# Altium schematic sheet 06_USB_To_UART.SchDoc
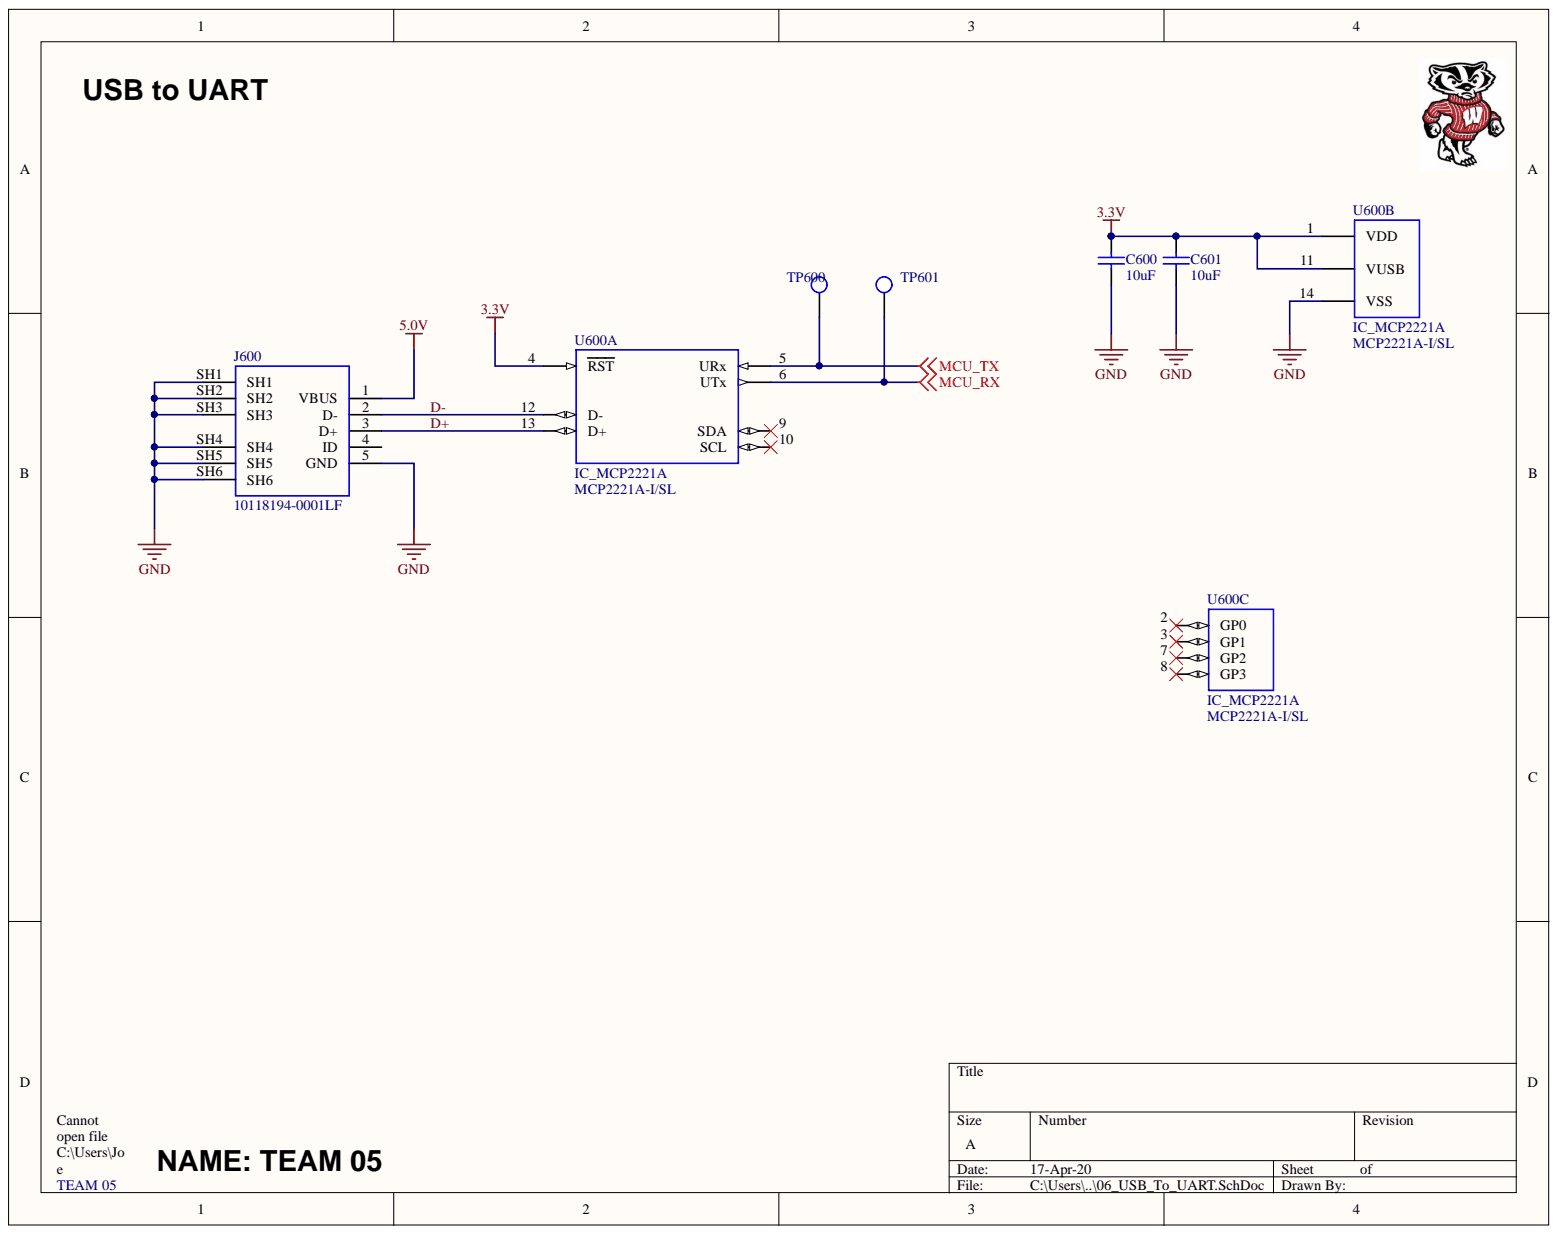
[diagram p0: 06_USB_To_UART.SchDoc]

## Parts
C600  10uF  [CAP_0805]
C601  10uF  [CAP_0805]
J600  Manufacturer Part Number: 10118194-0001LF  [USB_MICRO_B]  (hidden comment: USB_MICRO_B_10118194-0001LF)
TP600  TP_1206  [TP-SMC-1206]  (value not drawn)
TP601  TP_1206  [TP-SMC-1206]  (value not drawn)
U600  IC_MCP2221A  Manufacturer Part Number: MCP2221A-I/SL  [SOIC_MCP2221A]  (parts A,B,C)

## Nets
3.3V: C600.1 C601.1 U600.1(VDD) U600.4(RST) U600.11(VUSB)
5.0V: J600.1(VBUS)
GND: C600.2 C601.2 J600.5(GND) J600.SH1 J600.SH2 J600.SH3 J600.SH4 J600.SH5 J600.SH6 U600.14(VSS)
MCU_RX: TP601.1 U600.6(UTx)
MCU_TX: TP600.1 U600.5(URx)
D+: J600.3(D+) U600.13(D+)
D-: J600.2(D-) U600.12(D-)

Not connected: J600 4(ID); U600 2(GP0) 3(GP1) 7(GP2) 8(GP3) 9(SDA) 10(SCL)

## Library data of the parts
C600 C601 = CAP_0805_U_010.00: CAP CER 10UF 16V X7R 0805; Manufacturer: Samsung; Manufacturer Part Number: CL21A106KPCLQNC; Dielectric: X5R; Tolerance: 10%; Voltage Rating: 10V; Capacitance: 10uF; Case/Package: 0805; Case Code (Imperial): 0805; Case Code (Metric): 2012; Component Kind: Standard; ComponentLink1Description: https://datasheet.ciiva.com/25730/cl21a106kpclqnc-25730594.pdf; ComponentLink1URL: https://datasheet.ciiva.com/25730/cl21a106kpclqnc-25730594.pdf; ComponentLink3Description: https://datasheet.ciiva.com/26920/getdatasheetpartid-355170-26920201.pdf; ComponentLink3URL: https://datasheet.ciiva.com/26920/getdatasheetpartid-355170-26920201.pdf; Contact Plating: Tin; Depth: 1.25mm; Height: 0.85mm; Length: 2mm; Library Name: ece315-S20.SCHLIB; Max Operating Temperature: 85°C; Min Operating Temperature: -55°C; Mount: Surface Mount; Package Quantity: 1; Packaging: Tape and Reel; Pricing 1: 1=0.22, 10=0.148, 100=0.0749, 500=0.05122, 1000=0.04531 (USD); Radiation Hardening: No; Stock 1: 40000; Supplier 1: Digi-Key; Supplier Part Number 1: 1276-2402-1-ND; Thickness: 0.037inch; Width: 0.049inch
J600 = USB_MICRO_B_10118194-0001LF: CONN USB MICRO B RECPT SMT R/A; Manufacturer: Amphenol FCI; Connector Type: USB,Receptacle; Current Rating: 1.8A; Gender: Receptacle; Insulation Resistance: 1GR; Max Voltage Rating (AC): 100V; Number of Contacts: 5; Number of Ports: 1; Pitch: 0.65mm; Color: Black; Component Kind: Standard; Contact Material: Copper Alloy; Contact Plating: Gold; Depth: 5mm; Features: Shielded; Flammability Rating: UL94 V-0; Housing Material: Polymer; Lead Free: Lead Free; Length: 8.05mm; Library Name: ece315-S20.SCHLIB; Max Operating Temperature: 85°C; Min Operating Temperature: -55°C; Mount: Surface Mount,Horizontal; Number of Terminals: 5; Orientation: Right Angle; Package Quantity: 9600; Packaging: Tape and Reel; Radiation Hardening: No; Supplier: Digi-Key; Supplier Part Number: 609-4618-1-ND; Termination: Solder
TP600 TP601 = TP_1206: Test Point SMD 1206; Manufacturer: TE Connectivity (Tyco Electronics); Manufacturer Part Number: 1625854-1; Power Rating: N/R; Resistance Value: 0 Ohm; Case Size: 1206; Component Kind: Standard; ComponentLink1Description: https://datasheet.ciiva.com/6439/eng-ds-1773266-a-6439169.pdf; ComponentLink1URL: https://datasheet.ciiva.com/6439/eng-ds-1773266-a-6439169.pdf; ComponentLink2Description: https://datasheet.ciiva.com/30136/1773266-a-30136341.pdf; ComponentLink2URL: https://datasheet.ciiva.com/30136/1773266-a-30136341.pdf; ComponentLink3Description: https://datasheet.ciiva.com/6439/eng-ds-1773266-a-6439080.pdf; ComponentLink3URL: https://datasheet.ciiva.com/6439/eng-ds-1773266-a-6439080.pdf; ComponentLink4Description: https://datasheet.ciiva.com/6439/eng-ds-1773266-a-6439081.pdf; ComponentLink4URL: https://datasheet.ciiva.com/6439/eng-ds-1773266-a-6439081.pdf; ComponentLink5Description: https://datasheet.ciiva.com/6439/eng-ds-1773266-a-6439083.pdf; ComponentLink5URL: https://datasheet.ciiva.com/6439/eng-ds-1773266-a-6439083.pdf; Dimension: 3.2 x 1.6 x 1.25mm; Family: RC; Library Name: ece315-S20.SCHLIB; Mounting: Surface Mount; Operating Temperature: -55 to 125 C; Packaging: Tape and Reel; Part No: 1625854-1; Pricing 1: 1=0.28, 10=0.261, 25=0.224, 50=0.1902, 100=0.1828, 250=0.1641, 500=0.1567, 1000=0.1306 (USD); RoHS: Yes; Stock 1: 31937; Supplier 1: Digi-Key; Supplier Part Number 1: A106143CT-ND; Temperature Coefficient: N/R; Terminal Pitch: N/R; Termination Style: N/R; Tolerance: N/R
U600 = IC_MCP2221A: IC USB TO I2C/UART 14SOIC; Manufacturer: Microchip; Interface: I2C,UART; Operating Supply Current: 13mA; Case/Package: SOIC; Component Kind: Standard; ComponentLink1Description: Datasheet; ComponentLink1URL: http://ww1.microchip.com/downloads/en/DeviceDoc/20005565B.pdf; Library Name: ece315-S20.SCHLIB; Max Operating Temperature: 85°C; Min Operating Temperature: -40°C; Packaging: Rail/Tube; Pricing 1: 1=1.95, 25=1.6276, 100=1.4832 (USD); Stock 1: 1104; Supplier 1: Digi-Key; Supplier Part Number 1: MCP2221A-I/SL-ND
